# Altium schematic sheet MCU.SchDoc(MCU)
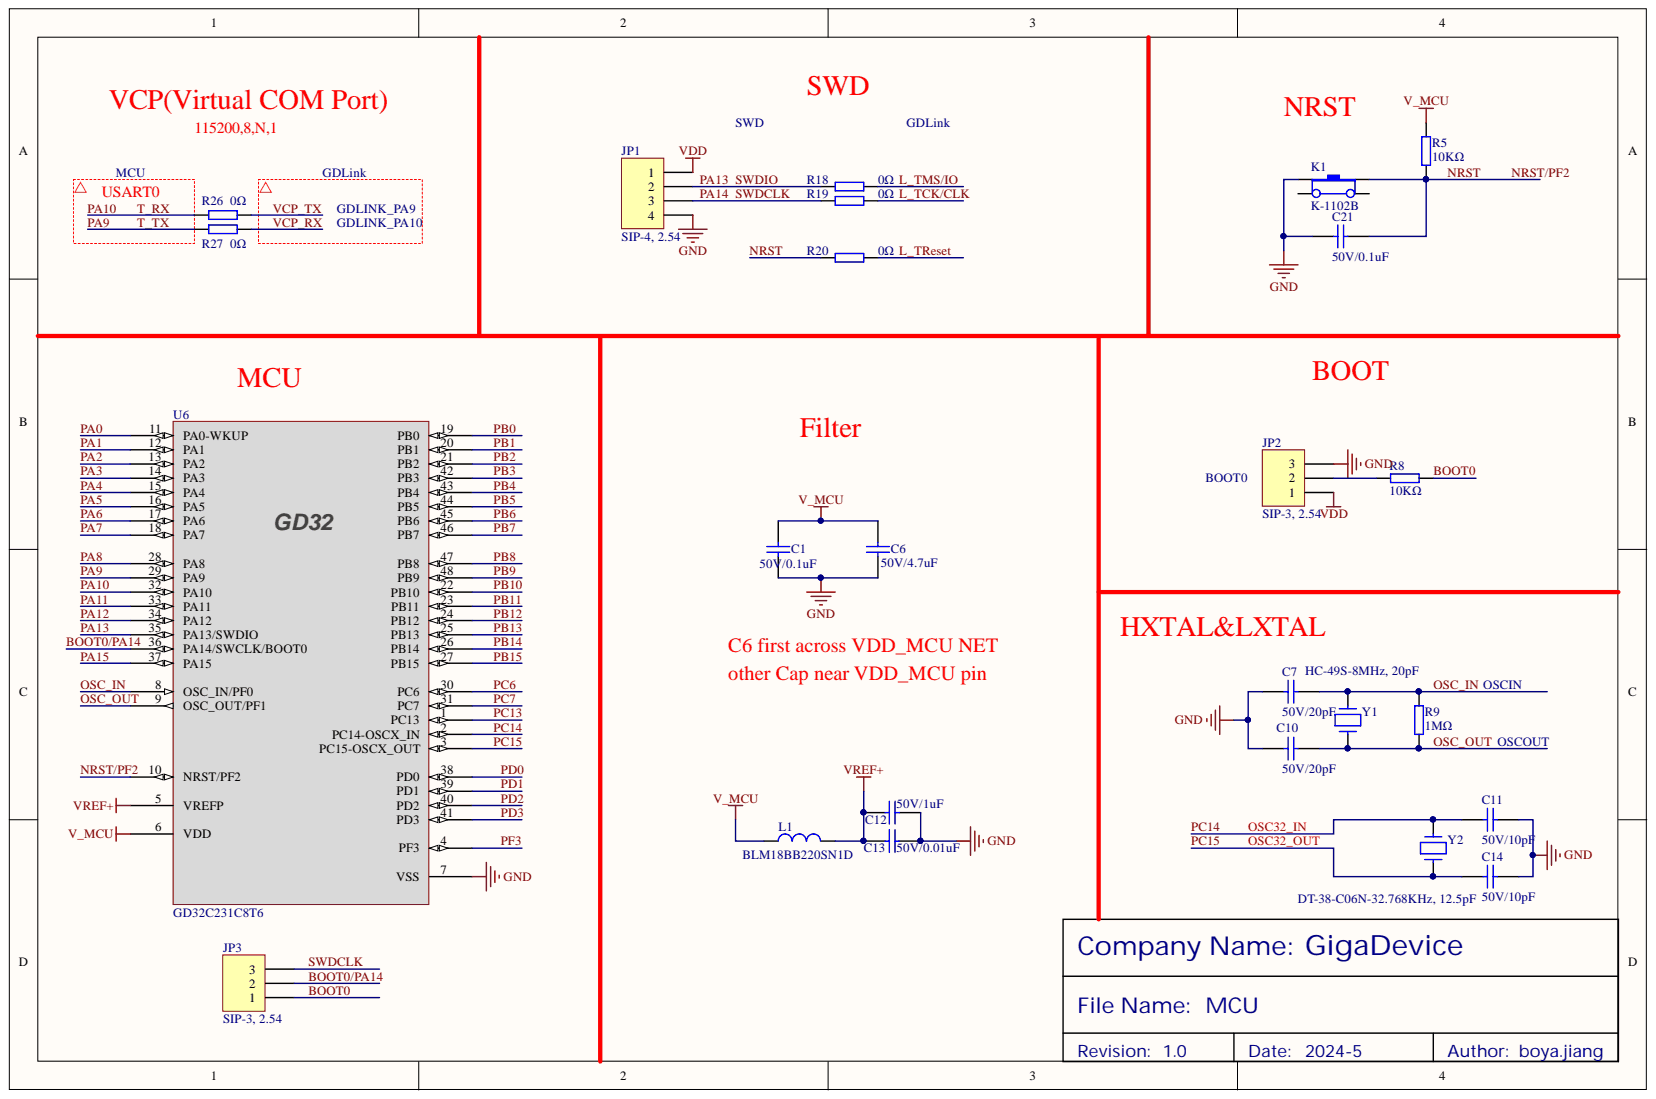
[diagram p0: MCU.SchDoc]

## Parts
C1  50V/0.1uF  [0603-C]
C6  50V/4.7uF  [0603-C]
C7  50V/20pF  [0603-C]
C10  50V/20pF  [0603-C]
C11  50V/10pF  [0603-C]
C12  50V/1uF  [0603-C]
C13  50V/0.01uF  [0603-C]
C14  50V/10pF  [0603-C]
C21  50V/0.1uF  [0603-C]
JP1  SIP-4, 2.54  [SIP-4]
JP2  SIP-3, 2.54  [SIP-3]
JP3  SIP-3, 2.54  [SIP-3]
K1  K-1102B  [K-1102B]
L1  BLM18BB220SN1D  [0603-R]
R5  10KΩ  [0603-R]
R8  10KΩ  [0603-R]
R9  1MΩ  [0603-R]
R18  0Ω  [0603-R]
R19  0Ω  [0603-R]
R20  0Ω  [0603-R]
R26  0Ω  [0603-R]
R27  0Ω  [0603-R]
U6  GD32C231C8T6  [LQFP-48]
Y1  HC-49S-8MHz, 20pF  [HC-49S]
Y2  DT-38-C06N-32.768KHz, 12.5pF  [DT-26]

## Nets
GND: C1.1 C6.1 C7.1 C10.1 C11.2 C12.1 C13.1 C14.1 C21.2 JP1.4 JP2.3 K1.1 U6.7(VSS)
V_MCU: C1.2 C6.2 L1.1 R5.2 U6.6(VDD)
VDD: JP1.1 JP2.1
VREF+: C12.2 C13.2 L1.2 U6.5(VREFP)
BOOT0: JP3.1 R8.1
BOOT0/PA14: JP3.2 U6.36(PA14/SWCLK/BOOT0)
L_TCK/CLK: R19.1
L_TMS/IO: R18.1
L_TReset: R20.1
NRST: C21.1 K1.3 R5.1 R20.2 U6.10(NRST/PF2)
OSC_IN: C7.2 R9.2 U6.8(OSC_IN/PF0) Y1.2
OSC_OUT: C10.2 R9.1 U6.9(OSC_OUT/PF1) Y1.1
PA0: U6.11(PA0-WKUP)
PA1: U6.12(PA1)
PA2: U6.13(PA2)
PA3: U6.14(PA3)
PA4: U6.15(PA4)
PA5: U6.16(PA5)
PA6: U6.17(PA6)
PA7: U6.18(PA7)
PA8: U6.28(PA8)
PA9: R27.2 U6.29(PA9)
PA10: R26.2 U6.32(PA10)
PA11: U6.33(PA11)
PA12: U6.34(PA12)
PA13: JP1.2 R18.2 U6.35(PA13/SWDIO)
PA14: JP1.3 JP3.3 R19.2
PA15: U6.37(PA15)
PB0: U6.19(PB0)
PB1: U6.20(PB1)
PB2: U6.21(PB2)
PB3: U6.42(PB3)
PB4: U6.43(PB4)
PB5: U6.44(PB5)
PB6: U6.45(PB6)
PB7: U6.46(PB7)
PB8: U6.47(PB8)
PB9: U6.48(PB9)
PB10: U6.22(PB10)
PB11: U6.23(PB11)
PB12: U6.24(PB12)
PB13: U6.25(PB13)
PB14: U6.26(PB14)
PB15: U6.27(PB15)
PC6: U6.30(PC6)
PC7: U6.31(PC7)
PC13: U6.1(PC13)
PC14: C11.1 U6.2(PC14-OSCX_IN) Y2.2
PC15: C14.2 U6.3(PC15-OSCX_OUT) Y2.1
PD0: U6.38(PD0)
PD1: U6.39(PD1)
PD2: U6.40(PD2)
PD3: U6.41(PD3)
PF3: U6.4(PF3)
VCP_RX: R27.1
VCP_TX: R26.1
NetJP2_2: JP2.2 R8.2

Not connected: K1 2 4

## Library data of the parts
C1 C6 C12 C13 C21 = 50V/0.1uF: 表贴电容; Component Kind: Standard; Library Name: KLTC-电容.SchLib
C7 C10 = 50V/20pF: 表贴电容; Component Kind: Standard; Library Name: KLTC-电容.SchLib
C11 C14 = 50V/10pF: 表贴电容; Component Kind: Standard; Library Name: KLTC-电容.SchLib
JP1 = HEADER 4: 单排插针; Component Kind: Standard; Library Name: KLTC-按键及接插件.SchLib
JP2 JP3 = HEADER 3: 单排插针; Component Kind: Standard; Library Name: KLTC-按键及接插件.SchLib
K1 = K-1102B: 普通6X6X7直插按键; Component Kind: Standard; Library Name: KLTC-按键及接插件.SchLib
L1 = BLM21AG221SN1D: 表贴磁珠; Component Kind: Standard; Library Name: KLTC-电感及磁珠.SchLib
R5 R8 = 10KΩ: 表贴电阻; Component Kind: Standard; Library Name: KLTC-电阻.SchLib
R9 = 1MΩ: 表贴电阻; Component Kind: Standard; Library Name: KLTC-电阻.SchLib
R18-R20 R26 R27 = 1.5KΩ: 表贴电阻; Component Kind: Standard; Library Name: KLTC-电阻.SchLib
U6 = GD32C231CxTx: 表贴MCU; Library Name: GD-MCU.SchLib; Component Kind: Standard
Y1 = HC-49S-G20SSA-8MHz: 直插晶振; Component Kind: Standard; Library Name: KLTC-晶振.SchLib
Y2 = DT-26-C06N-32.768KHz: 直插晶振; Component Kind: Standard; Library Name: KLTC-晶振.SchLib
